# Altium schematic sheet CANNON_ON_BD.SchDoc
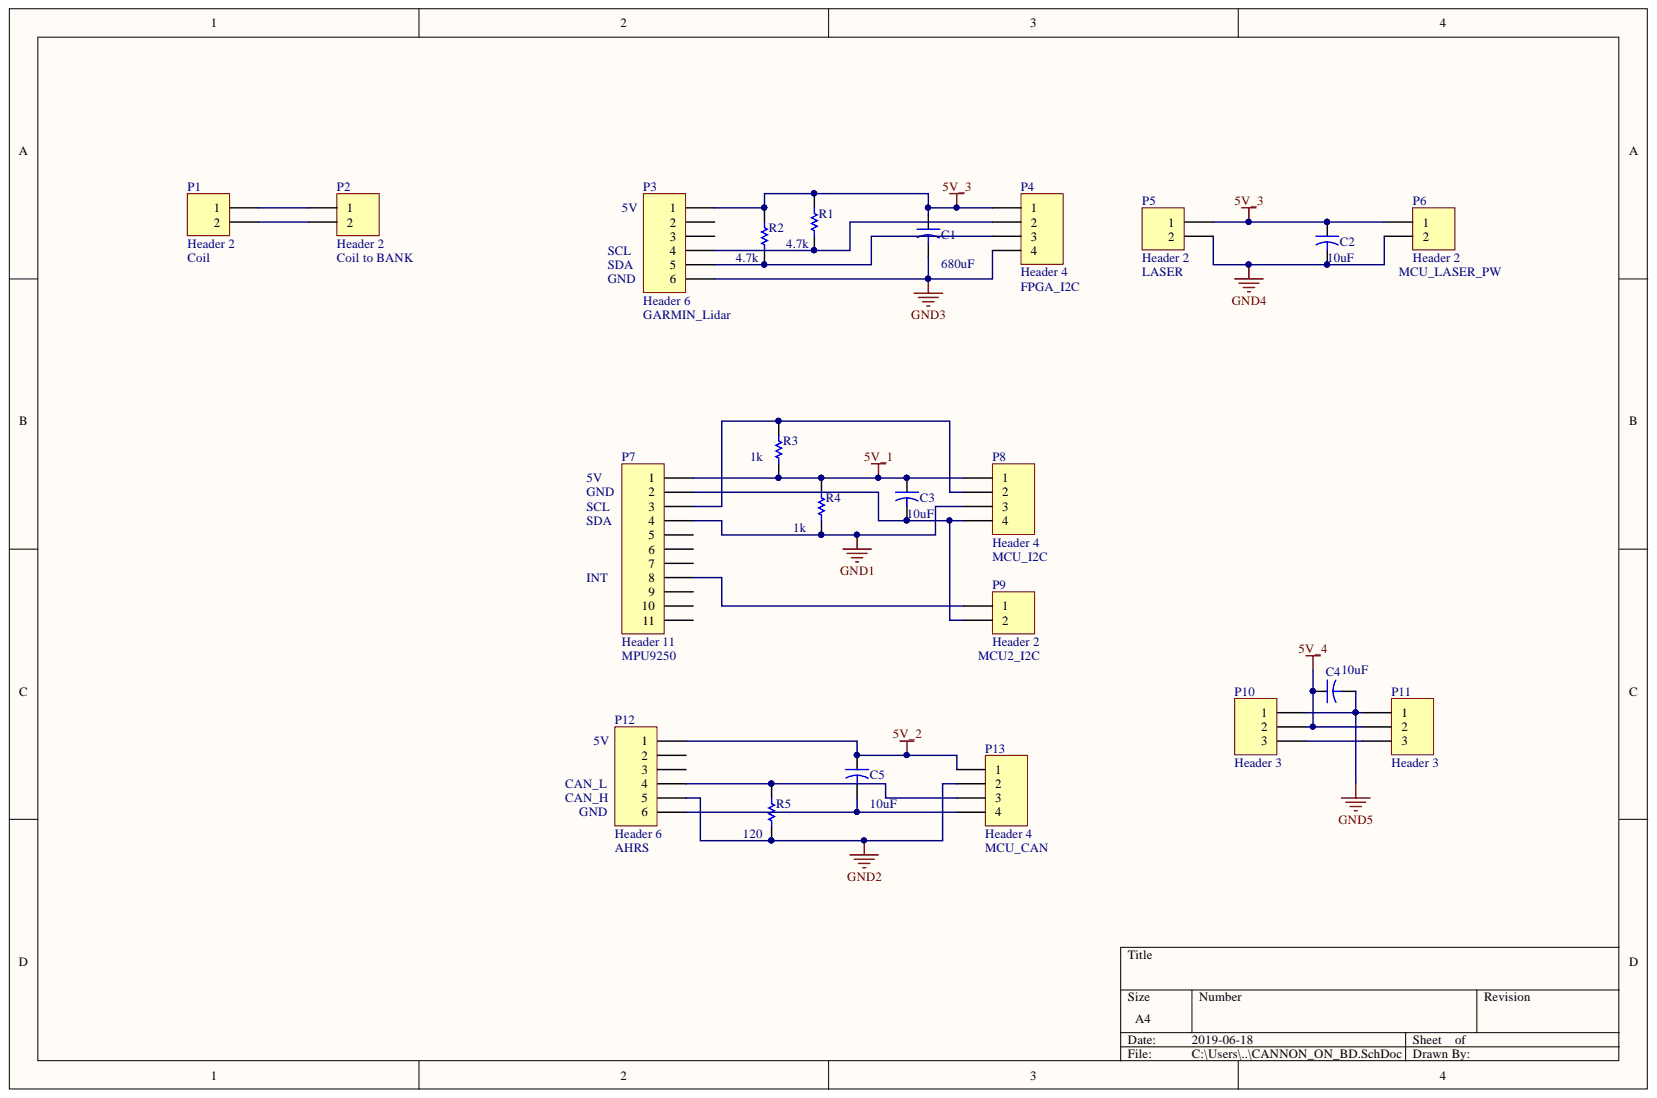
[diagram p0: CANNON_ON_BD.SchDoc]

## Parts
C1  value 680uF  [CAPR5-4X5]  (hidden comment: Cap2)
C2  value 10uF  [CAPR5-4X5]  (hidden comment: Cap2)
C3  value 10uF  [CAPR5-4X5]  (hidden comment: Cap2)
C4  value 10uF  [CAPR5-4X5]  (hidden comment: Cap2)
C5  value 10uF  [CAPR5-4X5]  (hidden comment: Cap2)
P1  Header 2  [HDR1X2]
P2  Header 2  [HDR1X2]
P3  Header 6  [HDR1X6]
P4  Header 4  [HDR1X4]
P5  Header 2  [HDR1X2]
P6  Header 2  [HDR1X2]
P7  Header 11  [HDR1X11]
P8  Header 4  [HDR1X4]
P9  Header 2  [HDR1X2]
P10  Header 3  [HDR1X3]
P11  Header 3  [HDR1X3]
P12  Header 6  [HDR1X6]
P13  Header 4  [HDR1X4]
R1  value 4.7k  [AXIAL-0.3]  (hidden comment: Res1)
R2  value 4.7k  [AXIAL-0.3]  (hidden comment: Res1)
R3  value 1k  [AXIAL-0.3]  (hidden comment: Res1)
R4  value 1k  [AXIAL-0.3]  (hidden comment: Res1)
R5  value 120  [AXIAL-0.3]  (hidden comment: Res1)

## Nets
5V_1: C3.1 P7.1 P8.1 R3.1 R4.2
5V_2: C5.1 P12.1 P13.1
5V_3: C1.1 C2.1 P3.1 P4.1 P5.1 P6.1 R1.2 R2.2
5V_4: C4.1 P10.2 P11.2
GND1: P7.4 P8.3 R4.1
GND2: P12.5 P13.2 R5.1
GND3: C1.2 P3.6 P4.4
GND4: C2.2 P5.2 P6.2
GND5: C4.2 P10.1 P11.1
NetC3_2: C3.2 P7.2 P8.4 P9.2
NetC5_2: C5.2 P12.6 P13.4
NetP1_1: P1.1 P2.1
NetP1_2: P1.2 P2.2
NetP3_4: P3.4 P4.2 R1.1
NetP3_5: P3.5 P4.3 R2.1
NetP7_3: P7.3 P8.2 R3.2
NetP7_8: P7.8 P9.1
NetP10_3: P10.3 P11.3
NetP12_4: P12.4 P13.3 R5.2

Not connected: P3 2 3; P7 5 6 7 9 10 11; P12 2 3

## Library data of the parts
C1-C5 = Cap2: Capacitor; Component Kind: Standard; Library Name: Miscellaneous Devices.IntLib; PackageDescription: Capacitor; 2 Leads; PackageReference: CAPR5-4X5; Published: 23-Sep-2002; Signal Integrity: Cap; Simulation: CAP; Publisher: Altium Limited
P1 P2 P5 P6 P9 = Header 2: Header, 2-Pin; Component Kind: Standard; LatestRevisionDate: 17-Jul-2002; LatestRevisionNote: Re-released for DXP Platform.; Library Name: Miscellaneous Connectors.IntLib; Signal Integrity: Connector; Publisher: Altium Limited
P3 P12 = Header 6: Header, 6-Pin; Component Kind: Standard; LatestRevisionDate: 17-Jul-2002; LatestRevisionNote: Re-released for DXP Platform.; Library Name: Miscellaneous Connectors.IntLib; Signal Integrity: Connector; Publisher: Altium Limited
P4 P8 P13 = Header 4: Header, 4-Pin; Component Kind: Standard; LatestRevisionDate: 17-Jul-2002; LatestRevisionNote: Re-released for DXP Platform.; Library Name: Miscellaneous Connectors.IntLib; Signal Integrity: Connector; Publisher: Altium Limited
P7 = Header 11: Header, 11-Pin; Component Kind: Standard; LatestRevisionDate: 17-Jul-2002; LatestRevisionNote: Re-released for DXP Platform.; Library Name: Miscellaneous Connectors.IntLib; Signal Integrity: Connector; Publisher: Altium Limited
P10 P11 = Header 3: Header, 3-Pin; Component Kind: Standard; LatestRevisionDate: 17-Jul-2002; LatestRevisionNote: Re-released for DXP Platform.; Library Name: Miscellaneous Connectors.IntLib; Signal Integrity: Connector; Publisher: Altium Limited
R1-R5 = Res1: Resistor; Component Kind: Standard; LatestRevisionDate: 17-Jul-2002; LatestRevisionNote: Re-released for DXP Platform.; Library Name: Miscellaneous Devices.IntLib; PackageDescription: Axial Device, Thru-Hole; 2 Leads; 0.3 in Pin Spacing; PackageReference: AXIAL-0.3; Published: 8-Jun-2000; Signal Integrity: Res; Simulation: RESISTOR; Publisher: Altium Limited
